# Altium schematic sheet LCD.SchDoc
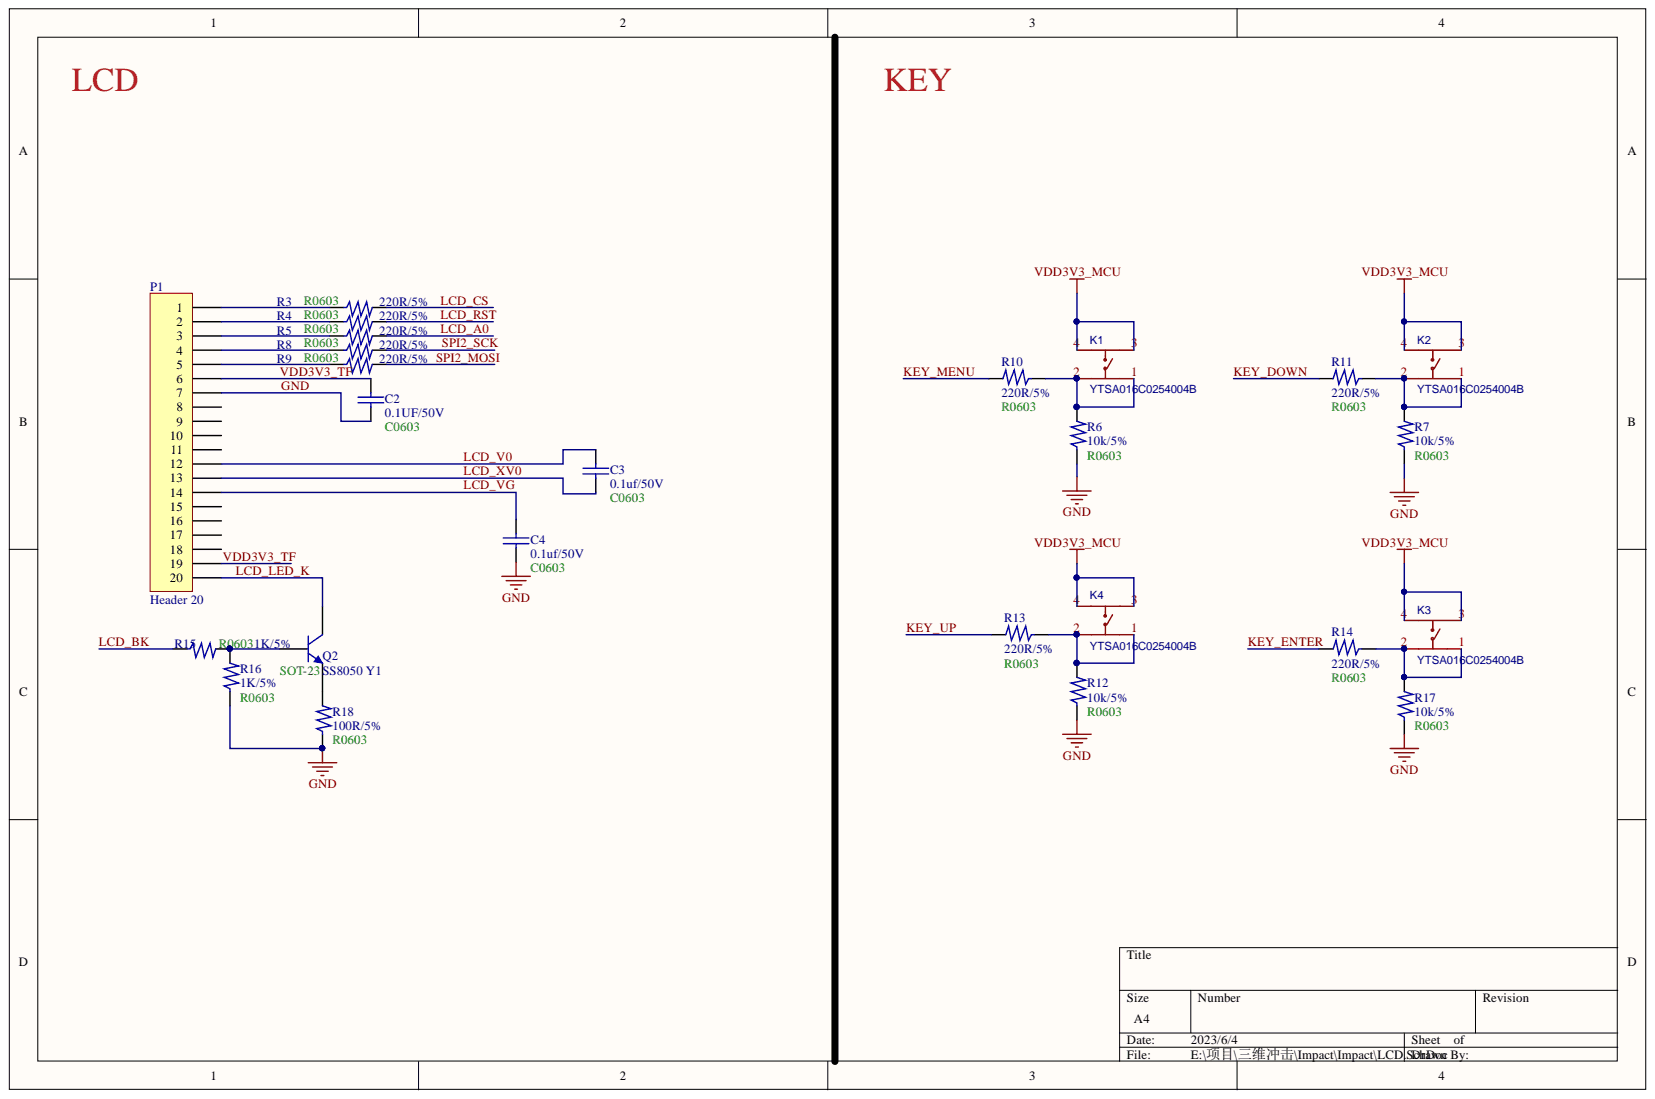
[diagram p0: LCD.SchDoc]

## Parts
C2  0.1UF/50V  [C0603]
C3  0.1uf/50V  [C0603]
C4  0.1uf/50V  [C0603]
K1  YTSA016C0254004B  [SMD6*6*4.5]
K2  YTSA016C0254004B  [SMD6*6*4.5]
K3  YTSA016C0254004B  [SMD6*6*4.5]
K4  YTSA016C0254004B  [SMD6*6*4.5]
P1  Header 20  [LCD]
Q2  SS8050 Y1  Package: SOT-23  [SOT-23(SOT-23-3)]
R3  220R/5%  [R0603]
R4  220R/5%  [R0603]
R5  220R/5%  [R0603]
R6  10k/5%  [R0603]
R7  10k/5%  [R0603]
R8  220R/5%  [R0603]
R9  220R/5%  [R0603]
R10  220R/5%  [R0603]
R11  220R/5%  [R0603]
R12  10k/5%  [R0603]
R13  220R/5%  [R0603]
R14  220R/5%  [R0603]
R15  1K/5%  [R0603]
R16  1K/5%  [R0603]
R17  10k/5%  [R0603]
R18  100R/5%  [R0603]

## Nets
VDD3V3_MCU: K1.3 K1.4 K2.3 K2.4 K3.3 K3.4 K4.3 K4.4
GND: C2.1 C4.2 P1.7 R6.2 R7.2 R12.2 R16.2 R17.2 R18.2
KEY_DOWN: R11.1
KEY_ENTER: R14.1
KEY_MENU: R10.1
KEY_UP: R13.1
LCD_A0: R5.1
LCD_BK: R15.2
LCD_CS: R3.1
LCD_LED_K: P1.20 Q2.3
LCD_RST: R4.1
LCD_V0: C3.1 P1.12
LCD_VG: C4.1 P1.14
LCD_XV0: C3.2 P1.13
SPI2_MOSI: R9.1
SPI2_SCK: R8.1
VDD3V3_TF: C2.2 P1.6 P1.19
NetK1_1: K1.1 K1.2 R6.1 R10.2
NetK2_1: K2.1 K2.2 R7.1 R11.2
NetK3_1: K3.1 K3.2 R14.2 R17.1
NetK4_1: K4.1 K4.2 R12.1 R13.2
NetP1_1: P1.1 R3.2
NetP1_2: P1.2 R4.2
NetP1_3: P1.3 R5.2
NetP1_4: P1.4 R8.2
NetP1_5: P1.5 R9.2
NetQ2_1: Q2.1 R15.1 R16.1
NetQ2_2: Q2.2 R18.1

Not connected: P1 8 9 10 11 15 16 17 18

## Library data of the parts
C2-C4 = CAP: Capacitor; Component Kind: Standard
K1-K4 = YTSA016C0254004B: BOM_Manufacturer: DGBZ(东莞博众); Contributor: LCSC; BOM_JLCPCB Part Class: Extended Part; BOM_Manufacturer Part: YTSA016C0254004B; BOM_Supplier: LCSC; BOM_Supplier Part: C2764970; Component Kind: Standard; spicePre: S; spiceSymbolName: YTSA016C0254004B
P1 = Header 20: Header, 20-Pin; Component Kind: Standard; LatestRevisionDate: 17-Jul-2002; LatestRevisionNote: Re-released for DXP Platform.; Library Name: Miscellaneous Connectors.IntLib; Signal Integrity: Connector; Publisher: Altium Limited
Q2 = SOT-23 SS8050 Y1: 三极管; Component Kind: Standard; ComponentLink1Description: 供应商链接; ComponentLink1URL: http://www.szlcsc.com/product/details_2507.html; Library Name: Miscellaneous Devices LC.IntLib; Supplier: LC; SuppliersPartNumber: C2150
R3-R18 = 电阻: Component Kind: Standard
